# Altium schematic sheet heating_schematic_1.SchDoc
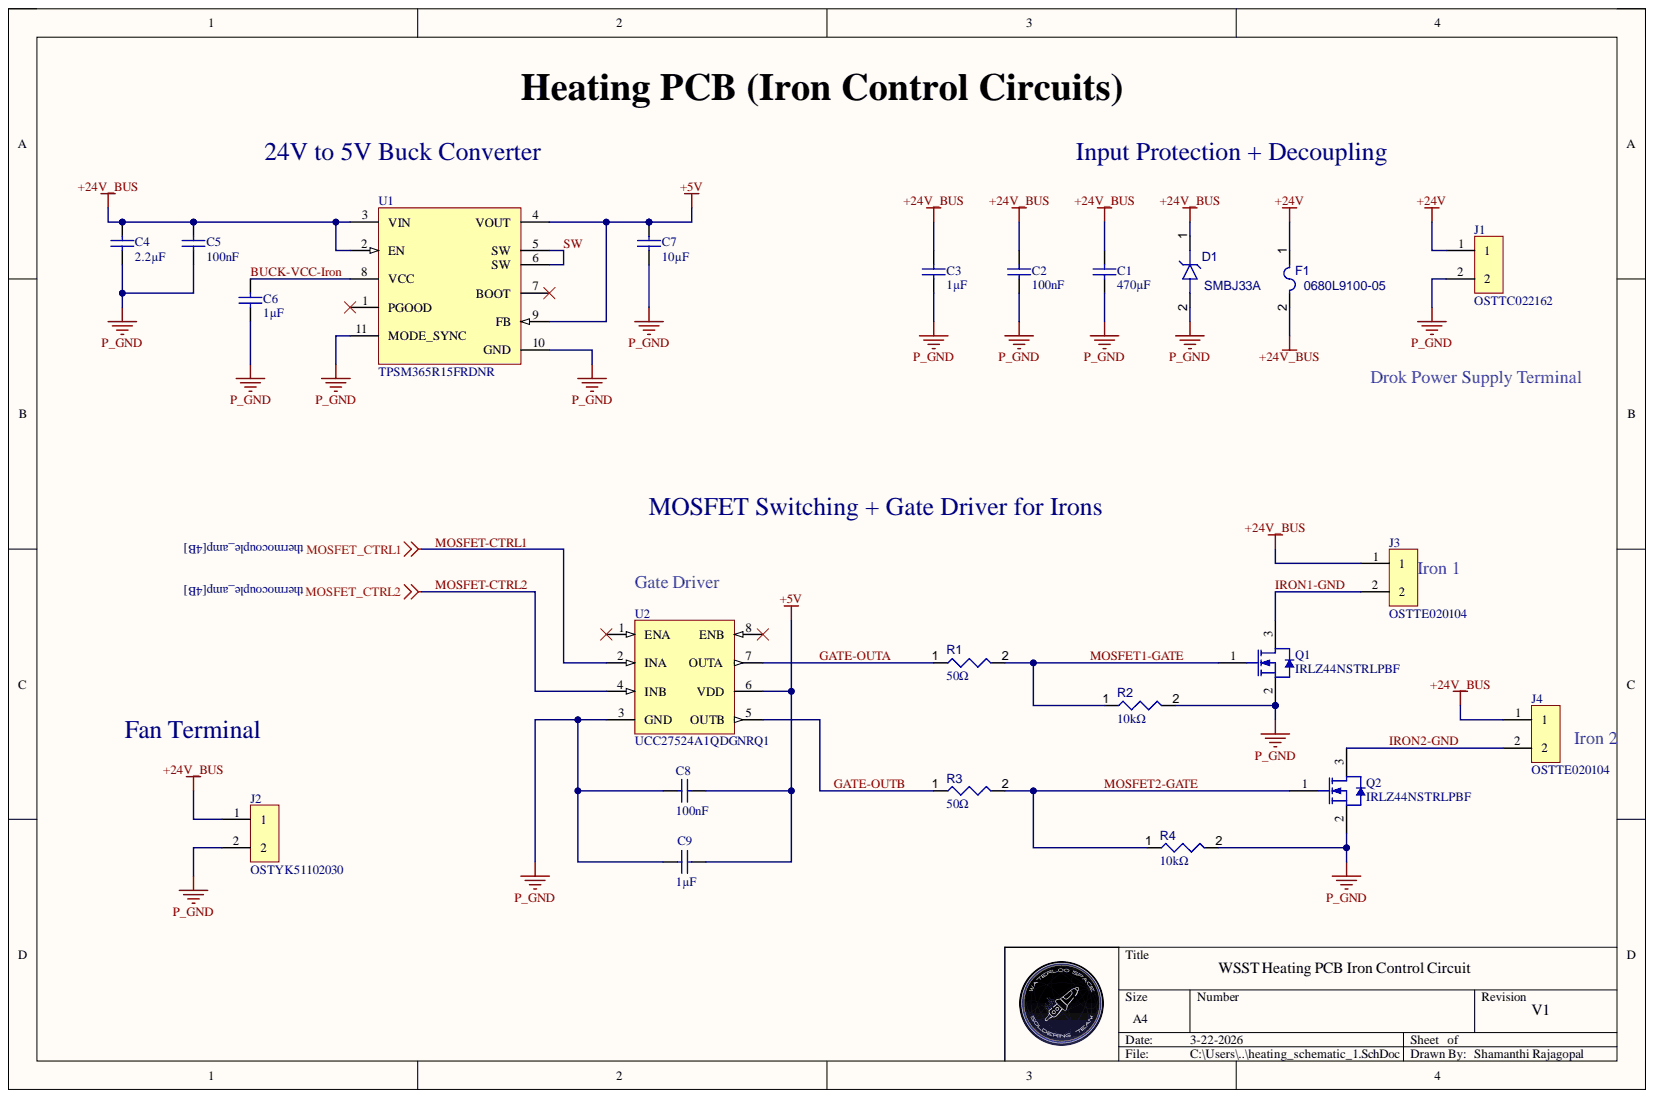
[diagram p0: heating_schematic_1.SchDoc]

## Parts
C1  value 470µF  (hidden comment: EEU-FC1H471L)
C2  value 100nF  (hidden comment: CC0603KRX7R9BB104)
C3  value 1µF  (hidden comment: CC0603KRX7R9BB105)
C4  value 2.2µF  (hidden comment: GRM32ER72A225KA35L)
C5  value 100nF  (hidden comment: GRM188R72A104KA35D)
C6  value 1µF  (hidden comment: EMK107B7105KA-T)
C7  value 10µF  (hidden comment: C0805C106K8PACTU)
C8  value 100nF  (hidden comment: GRM188R72A104KA35D (2))
C9  value 1µF  (hidden comment: CL10B105KA8NNNC)
D1  SMBJ33A
F1  0680L9100-05
J1  OSTTC022162
J2  OSTYK51102030
J3  OSTTE020104
J4  OSTTE020104
Q1  IRLZ44NSTRLPBF  [PCBComponent_1]
Q2  IRLZ44NSTRLPBF  [PCBComponent_1]
R1  value 50Ω  (hidden comment: CRCW060350R0FKTA)
R2  value 10kΩ  (hidden comment: RC0603FR-0710KL)
R3  value 50Ω  (hidden comment: CRCW060350R0FKTA)
R4  value 10kΩ  (hidden comment: RC0603FR-0710KL)
U1  TPSM365R15FRDNR
U2  UCC27524A1QDGNRQ1

## Nets
+5V: C7.2 C8.1 C9.1 U1.4(VOUT) U1.9(FB) U2.6(VDD)
+24V: F1.1 J1.1
+24V_BUS: C1.2 C2.2 C3.2 C4.2 C5.2 D1.1 F1.2 J2.1 J3.1 J4.1 U1.2(EN) U1.3(VIN)
P_GND: C1.1 C2.1 C3.1 C4.1 C5.1 C6.1 C7.1 C8.2 C9.2 D1.2 J1.2 J2.2 Q1.2 Q2.2 R2.2 R4.2 U1.10(GND) U1.11(MODE_SYNC) U2.3(GND)
BUCK-VCC-Iron: C6.2 U1.8(VCC)
GATE-OUTA: R1.1 U2.7(OUTA)
GATE-OUTB: R3.1 U2.5(OUTB)
IRON1-GND: J3.2 Q1.3
IRON2-GND: J4.2 Q2.3
MOSFET1-GATE: Q1.1 R1.2 R2.1
MOSFET2-GATE: Q2.1 R3.2 R4.1
MOSFET-CTRL1: U2.2(INA)
MOSFET-CTRL2: U2.4(INB)
SW: U1.5(SW) U1.6(SW)

Not connected: U1 1(PGOOD) 7(BOOT); U2 1(ENA) 8(ENB)
